# Altium schematic sheet flash.SchDoc(flash)
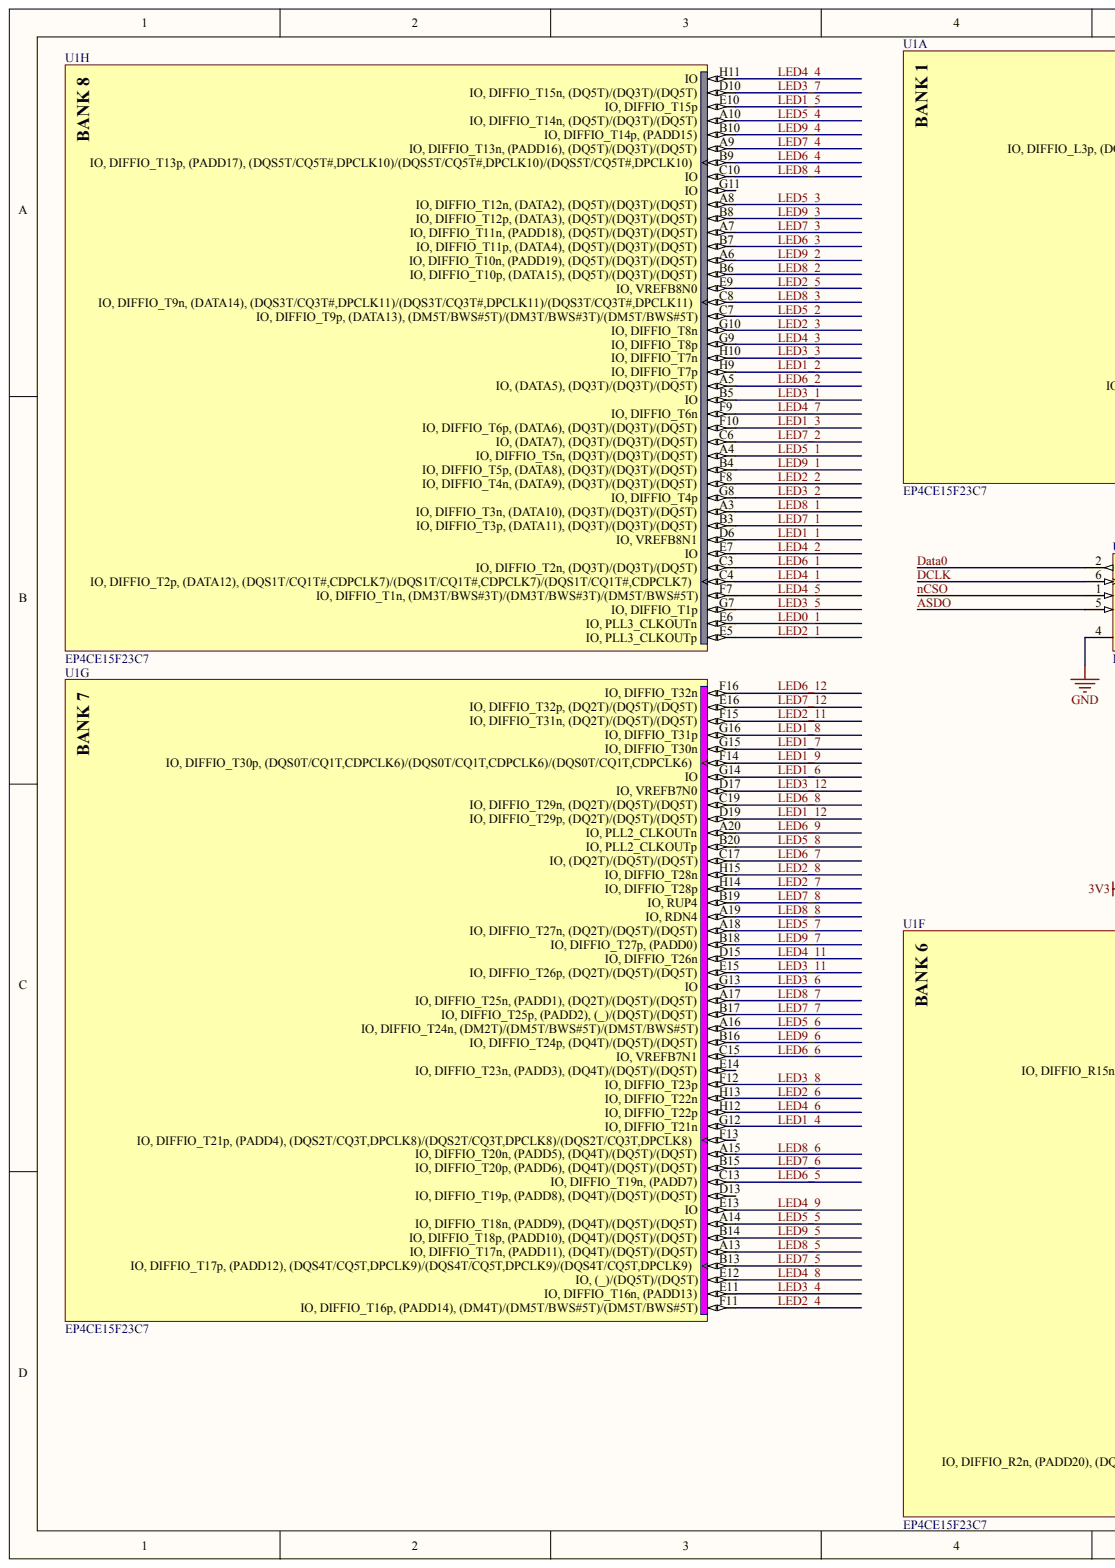
[diagram p0: flash.SchDoc, left]
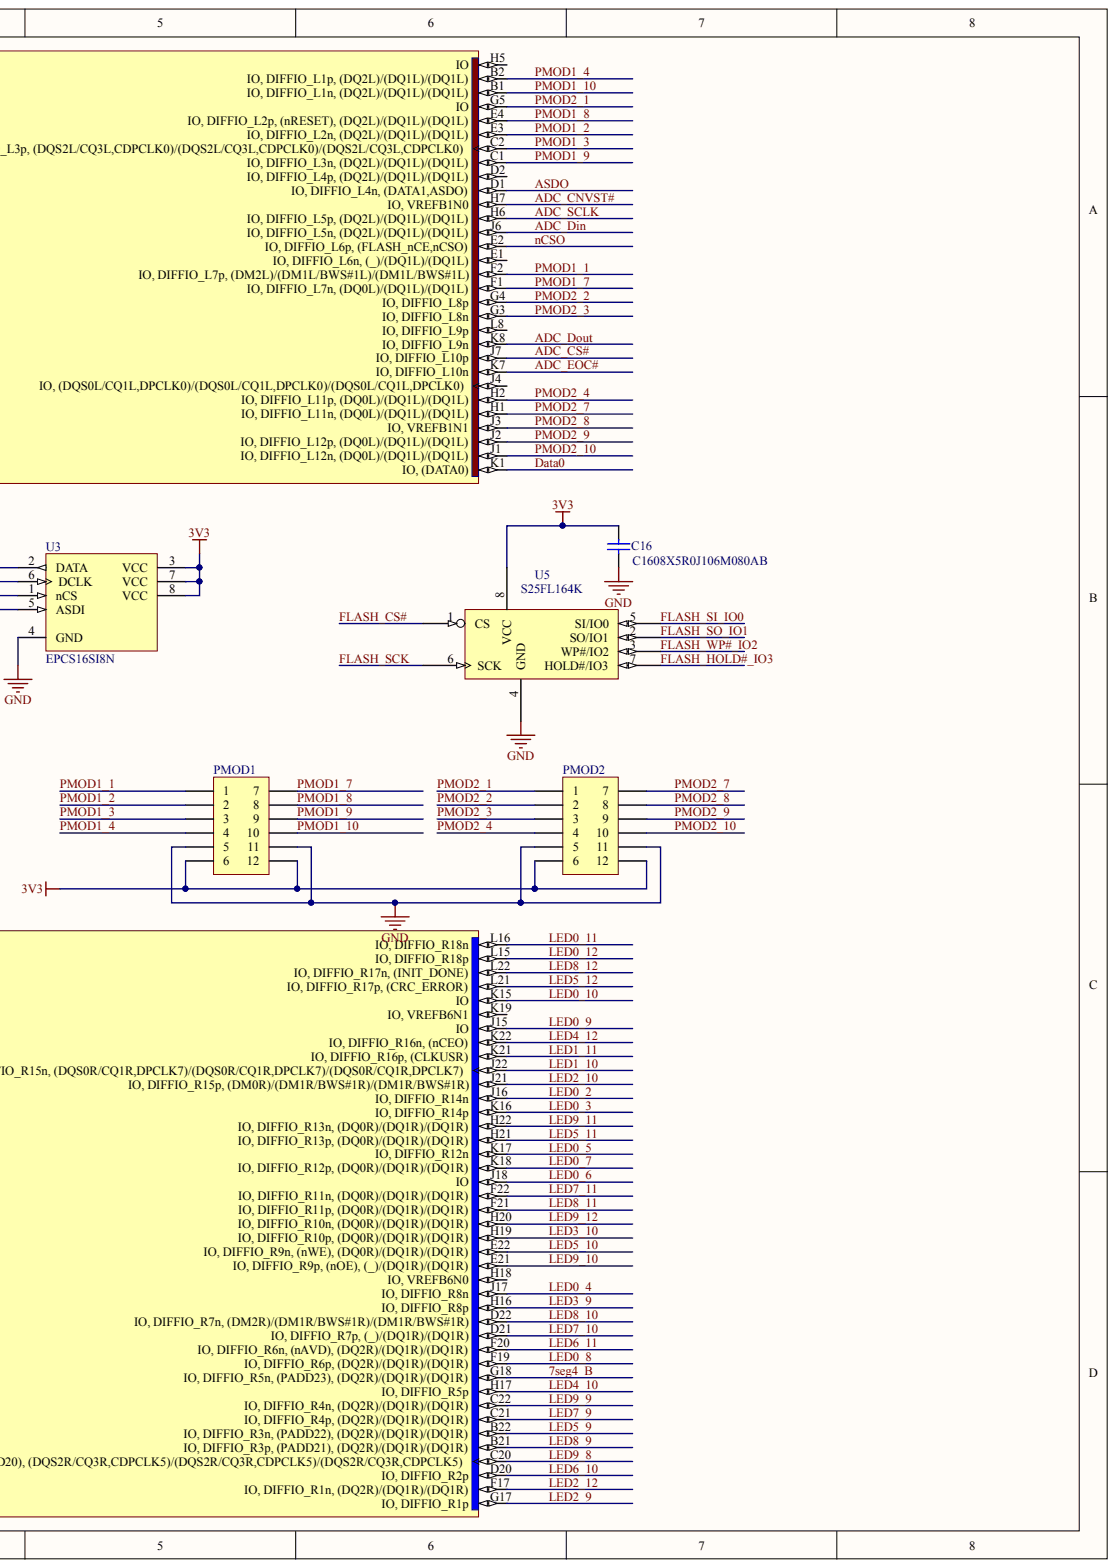
[diagram p1: flash.SchDoc, right]

## Parts
C16  C1608X5R0J106M080AB  [CAPC1608X80]
PMOD1  Header 6X2A  [PMOD_HOST]  (value not drawn)
PMOD2  Header 6X2A  [PMOD_HOST]  (value not drawn)
U1  EP4CE15F23C7  [FBGA484_A-240]  (parts A,F,G,H)
U3  EPCS16SI8N  [SOIC8_N]
U5  S25FL164K  [SOIC127P600X175-8N]

## Nets
3V3: C16.2 PMOD1.6 PMOD1.12 PMOD2.6 PMOD2.12 U3.3(VCC) U3.7(VCC) U3.8(VCC) U5.8(VCC)
GND: C16.1 PMOD1.5 PMOD1.11 PMOD2.5 PMOD2.11 U3.4(GND) U5.4(GND)
7SEG4_B: U1.G18(IO,_DIFFIO_R5n,_(PADD23),_(DQ2R)/(DQ1R)/(DQ1R))
ADC_CNVST#: U1.H7(IO,_VREFB1N0)
ADC_CS#: U1.J7(IO,_DIFFIO_L10p)
ADC_DIN: U1.J6(IO,_DIFFIO_L5n,_(DQ2L)/(DQ1L)/(DQ1L))
ADC_DOUT: U1.K8(IO,_DIFFIO_L9n)
ADC_EOC#: U1.K7(IO,_DIFFIO_L10n)
ADC_SCLK: U1.H6(IO,_DIFFIO_L5p,_(DQ2L)/(DQ1L)/(DQ1L))
ASDO: U1.D1(IO,_DIFFIO_L4n,_(DATA1,ASDO)) U3.5(ASDI)
DATA0: U1.K1(IO,_(DATA0)) U3.2(DATA)
DCLK: U3.6(DCLK)
FLASH_CS#: U5.1(CS)
FLASH_HOLD#_IO3: U5.7(HOLD#/IO3)
FLASH_SCK: U5.6(SCK)
FLASH_SI_IO0: U5.5(SI/IO0)
FLASH_SO_IO1: U5.2(SO/IO1)
FLASH_WP#_IO2: U5.3(WP#/IO2)
LED0_1: U1.E6(IO,_PLL3_CLKOUTn)
LED0_2: U1.J16(IO,_DIFFIO_R14n)
LED0_3: U1.K16(IO,_DIFFIO_R14p)
LED0_4: U1.J17(IO,_DIFFIO_R8n)
LED0_5: U1.K17(IO,_DIFFIO_R12n)
LED0_6: U1.J18(IO)
LED0_7: U1.K18(IO,_DIFFIO_R12p,_(DQ0R)/(DQ1R)/(DQ1R))
LED0_8: U1.F19(IO,_DIFFIO_R6p,_(DQ2R)/(DQ1R)/(DQ1R))
LED0_9: U1.J15(IO)
LED0_10: U1.K15(IO)
LED0_11: U1.L16(IO,_DIFFIO_R18n)
LED0_12: U1.L15(IO,_DIFFIO_R18p)
LED1_1: U1.D6(IO,_VREFB8N1)
LED1_2: U1.H9(IO,_DIFFIO_T7p)
LED1_3: U1.F10(IO,_DIFFIO_T6p,_(DATA6),_(DQ3T)/(DQ3T)/(DQ5T))
LED1_4: U1.G12(IO,_DIFFIO_T21n)
LED1_5: U1.E10(IO,_DIFFIO_T15p)
LED1_6: U1.G14(IO)
LED1_7: U1.G15(IO,_DIFFIO_T30n)
LED1_8: U1.G16(IO,_DIFFIO_T31p)
LED1_9: U1.F14(IO,_DIFFIO_T30p,_(DQS0T/CQ1T,CDPCLK6)/(DQS0T/CQ1T,CDPCLK6)/(DQS0T/CQ1T,CDPCLK6))
LED1_10: U1.J22(IO,_DIFFIO_R15n,_(DQS0R/CQ1R,DPCLK7)/(DQS0R/CQ1R,DPCLK7)/(DQS0R/CQ1R,DPCLK7))
LED1_11: U1.K21(IO,_DIFFIO_R16p,_(CLKUSR))
LED1_12: U1.D19(IO,_DIFFIO_T29p,_(DQ2T)/(DQ5T)/(DQ5T))
LED2_1: U1.E5(IO,_PLL3_CLKOUTp)
LED2_2: U1.F8(IO,_DIFFIO_T4n,_(DATA9),_(DQ3T)/(DQ3T)/(DQ5T))
LED2_3: U1.G10(IO,_DIFFIO_T8n)
LED2_4: U1.F11(IO,_DIFFIO_T16p,_(PADD14),_(DM4T)/(DM5T/BWS#5T)/(DM5T/BWS#5T))
LED2_5: U1.E9(IO,_VREFB8N0)
LED2_6: U1.H13(IO,_DIFFIO_T22n)
LED2_7: U1.H14(IO,_DIFFIO_T28p)
LED2_8: U1.H15(IO,_DIFFIO_T28n)
LED2_9: U1.G17(IO,_DIFFIO_R1p)
LED2_10: U1.J21(IO,_DIFFIO_R15p,_(DM0R)/(DM1R/BWS#1R)/(DM1R/BWS#1R))
LED2_11: U1.F15(IO,_DIFFIO_T31n,_(DQ2T)/(DQ5T)/(DQ5T))
LED2_12: U1.F17(IO,_DIFFIO_R1n,_(DQ2R)/(DQ1R)/(DQ1R))
LED3_1: U1.B5(IO)
LED3_2: U1.G8(IO,_DIFFIO_T4p)
LED3_3: U1.H10(IO,_DIFFIO_T7n)
LED3_4: U1.E11(IO,_DIFFIO_T16n,_(PADD13))
LED3_5: U1.G7(IO,_DIFFIO_T1p)
LED3_6: U1.G13(IO)
LED3_7: U1.D10(IO,_DIFFIO_T15n,_(DQ5T)/(DQ3T)/(DQ5T))
LED3_8: U1.F12(IO,_DIFFIO_T23p)
LED3_9: U1.H16(IO,_DIFFIO_R8p)
LED3_10: U1.H19(IO,_DIFFIO_R10p,_(DQ0R)/(DQ1R)/(DQ1R))
LED3_11: U1.E15(IO,_DIFFIO_T26p,_(DQ2T)/(DQ5T)/(DQ5T))
LED3_12: U1.D17(IO,_VREFB7N0)
LED4_1: U1.C4(IO,_DIFFIO_T2p,_(DATA12),_(DQS1T/CQ1T#,CDPCLK7)/(DQS1T/CQ1T#,CDPCLK7)/(DQS1T/CQ1T#,CDPCLK7))
LED4_2: U1.E7(IO)
LED4_3: U1.G9(IO,_DIFFIO_T8p)
LED4_4: U1.H11(IO)
LED4_5: U1.F7(IO,_DIFFIO_T1n,_(DM3T/BWS#3T)/(DM3T/BWS#3T)/(DM5T/BWS#5T))
LED4_6: U1.H12(IO,_DIFFIO_T22p)
LED4_7: U1.F9(IO,_DIFFIO_T6n)
LED4_8: U1.E12(IO,_(_)/(DQ5T)/(DQ5T))
LED4_9: U1.E13(IO)
LED4_10: U1.H17(IO,_DIFFIO_R5p)
LED4_11: U1.D15(IO,_DIFFIO_T26n)
LED4_12: U1.K22(IO,_DIFFIO_R16n,_(nCEO))
LED5_1: U1.A4(IO,_DIFFIO_T5n,_(DQ3T)/(DQ3T)/(DQ5T))
LED5_2: U1.C7(IO,_DIFFIO_T9p,_(DATA13),_(DM5T/BWS#5T)/(DM3T/BWS#3T)/(DM5T/BWS#5T))
LED5_3: U1.A8(IO,_DIFFIO_T12n,_(DATA2),_(DQ5T)/(DQ3T)/(DQ5T))
LED5_4: U1.A10(IO,_DIFFIO_T14n,_(DQ5T)/(DQ3T)/(DQ5T))
LED5_5: U1.A14(IO,_DIFFIO_T18n,_(PADD9),_(DQ4T)/(DQ5T)/(DQ5T))
LED5_6: U1.A16(IO,_DIFFIO_T24n,_(DM2T)/(DM5T/BWS#5T)/(DM5T/BWS#5T))
LED5_7: U1.A18(IO,_DIFFIO_T27n,_(DQ2T)/(DQ5T)/(DQ5T))
LED5_8: U1.B20(IO,_PLL2_CLKOUTp)
LED5_9: U1.B22(IO,_DIFFIO_R3n,_(PADD22),_(DQ2R)/(DQ1R)/(DQ1R))
LED5_10: U1.E22(IO,_DIFFIO_R9n,_(nWE),_(DQ0R)/(DQ1R)/(DQ1R))
LED5_11: U1.H21(IO,_DIFFIO_R13p,_(DQ0R)/(DQ1R)/(DQ1R))
LED5_12: U1.L21(IO,_DIFFIO_R17p,_(CRC_ERROR))
LED6_1: U1.C3(IO,_DIFFIO_T2n,_(DQ3T)/(DQ3T)/(DQ5T))
LED6_2: U1.A5(IO,_(DATA5),_(DQ3T)/(DQ3T)/(DQ5T))
LED6_3: U1.B7(IO,_DIFFIO_T11p,_(DATA4),_(DQ5T)/(DQ3T)/(DQ5T))
LED6_4: U1.B9(IO,_DIFFIO_T13p,_(PADD17),_(DQS5T/CQ5T#,DPCLK10)/(DQS5T/CQ5T#,DPCLK10)/(DQS5T/CQ5T#,DPCLK10))
LED6_5: U1.C13(IO,_DIFFIO_T19n,_(PADD7))
LED6_6: U1.C15(IO,_VREFB7N1)
LED6_7: U1.C17(IO,_(DQ2T)/(DQ5T)/(DQ5T))
LED6_8: U1.C19(IO,_DIFFIO_T29n,_(DQ2T)/(DQ5T)/(DQ5T))
LED6_9: U1.A20(IO,_PLL2_CLKOUTn)
LED6_10: U1.D20(IO,_DIFFIO_R2p)
LED6_11: U1.F20(IO,_DIFFIO_R6n,_(nAVD),_(DQ2R)/(DQ1R)/(DQ1R))
LED6_12: U1.F16(IO,_DIFFIO_T32n)
LED7_1: U1.B3(IO,_DIFFIO_T3p,_(DATA11),_(DQ3T)/(DQ3T)/(DQ5T))
LED7_2: U1.C6(IO,_(DATA7),_(DQ3T)/(DQ3T)/(DQ5T))
LED7_3: U1.A7(IO,_DIFFIO_T11n,_(PADD18),_(DQ5T)/(DQ3T)/(DQ5T))
LED7_4: U1.A9(IO,_DIFFIO_T13n,_(PADD16),_(DQ5T)/(DQ3T)/(DQ5T))
LED7_5: U1.B13(IO,_DIFFIO_T17p,_(PADD12),_(DQS4T/CQ5T,DPCLK9)/(DQS4T/CQ5T,DPCLK9)/(DQS4T/CQ5T,DPCLK9))
LED7_6: U1.B15(IO,_DIFFIO_T20p,_(PADD6),_(DQ4T)/(DQ5T)/(DQ5T))
LED7_7: U1.B17(IO,_DIFFIO_T25p,_(PADD2),_(_)/(DQ5T)/(DQ5T))
LED7_8: U1.B19(IO,_RUP4)
LED7_9: U1.C21(IO,_DIFFIO_R4p,_(DQ2R)/(DQ1R)/(DQ1R))
LED7_10: U1.D21(IO,_DIFFIO_R7p,_(_)/(DQ1R)/(DQ1R))
LED7_11: U1.F22(IO,_DIFFIO_R11n,_(DQ0R)/(DQ1R)/(DQ1R))
LED7_12: U1.E16(IO,_DIFFIO_T32p,_(DQ2T)/(DQ5T)/(DQ5T))
LED8_1: U1.A3(IO,_DIFFIO_T3n,_(DATA10),_(DQ3T)/(DQ3T)/(DQ5T))
LED8_2: U1.B6(IO,_DIFFIO_T10p,_(DATA15),_(DQ5T)/(DQ3T)/(DQ5T))
LED8_3: U1.C8(IO,_DIFFIO_T9n,_(DATA14),_(DQS3T/CQ3T#,DPCLK11)/(DQS3T/CQ3T#,DPCLK11)/(DQS3T/CQ3T#,DPCLK11))
LED8_4: U1.C10(IO)
LED8_5: U1.A13(IO,_DIFFIO_T17n,_(PADD11),_(DQ4T)/(DQ5T)/(DQ5T))
LED8_6: U1.A15(IO,_DIFFIO_T20n,_(PADD5),_(DQ4T)/(DQ5T)/(DQ5T))
LED8_7: U1.A17(IO,_DIFFIO_T25n,_(PADD1),_(DQ2T)/(DQ5T)/(DQ5T))
LED8_8: U1.A19(IO,_RDN4)
LED8_9: U1.B21(IO,_DIFFIO_R3p,_(PADD21),_(DQ2R)/(DQ1R)/(DQ1R))
LED8_10: U1.D22(IO,_DIFFIO_R7n,_(DM2R)/(DM1R/BWS#1R)/(DM1R/BWS#1R))
LED8_11: U1.F21(IO,_DIFFIO_R11p,_(DQ0R)/(DQ1R)/(DQ1R))
LED8_12: U1.L22(IO,_DIFFIO_R17n,_(INIT_DONE))
LED9_1: U1.B4(IO,_DIFFIO_T5p,_(DATA8),_(DQ3T)/(DQ3T)/(DQ5T))
LED9_2: U1.A6(IO,_DIFFIO_T10n,_(PADD19),_(DQ5T)/(DQ3T)/(DQ5T))
LED9_3: U1.B8(IO,_DIFFIO_T12p,_(DATA3),_(DQ5T)/(DQ3T)/(DQ5T))
LED9_4: U1.B10(IO,_DIFFIO_T14p,_(PADD15))
LED9_5: U1.B14(IO,_DIFFIO_T18p,_(PADD10),_(DQ4T)/(DQ5T)/(DQ5T))
LED9_6: U1.B16(IO,_DIFFIO_T24p,_(DQ4T)/(DQ5T)/(DQ5T))
LED9_7: U1.B18(IO,_DIFFIO_T27p,_(PADD0))
LED9_8: U1.C20(IO,_DIFFIO_R2n,_(PADD20),_(DQS2R/CQ3R,CDPCLK5)/(DQS2R/CQ3R,CDPCLK5)/(DQS2R/CQ3R,CDPCLK5))
LED9_9: U1.C22(IO,_DIFFIO_R4n,_(DQ2R)/(DQ1R)/(DQ1R))
LED9_10: U1.E21(IO,_DIFFIO_R9p,_(nOE),_(_)/(DQ1R)/(DQ1R))
LED9_11: U1.H22(IO,_DIFFIO_R13n,_(DQ0R)/(DQ1R)/(DQ1R))
LED9_12: U1.H20(IO,_DIFFIO_R10n,_(DQ0R)/(DQ1R)/(DQ1R))
NCSO: U1.E2(IO,_DIFFIO_L6p,_(FLASH_nCE,nCSO)) U3.1(nCS)
PMOD1_1: PMOD1.1 U1.F2(IO,_DIFFIO_L7p,_(DM2L)/(DM1L/BWS#1L)/(DM1L/BWS#1L))
PMOD1_2: PMOD1.2 U1.E3(IO,_DIFFIO_L2n,_(DQ2L)/(DQ1L)/(DQ1L))
PMOD1_3: PMOD1.3 U1.C2(IO,_DIFFIO_L3p,_(DQS2L/CQ3L,CDPCLK0)/(DQS2L/CQ3L,CDPCLK0)/(DQS2L/CQ3L,CDPCLK0))
PMOD1_4: PMOD1.4 U1.B2(IO,_DIFFIO_L1p,_(DQ2L)/(DQ1L)/(DQ1L))
PMOD1_7: PMOD1.7 U1.F1(IO,_DIFFIO_L7n,_(DQ0L)/(DQ1L)/(DQ1L))
PMOD1_8: PMOD1.8 U1.E4(IO,_DIFFIO_L2p,_(nRESET),_(DQ2L)/(DQ1L)/(DQ1L))
PMOD1_9: PMOD1.9 U1.C1(IO,_DIFFIO_L3n,_(DQ2L)/(DQ1L)/(DQ1L))
PMOD1_10: PMOD1.10 U1.B1(IO,_DIFFIO_L1n,_(DQ2L)/(DQ1L)/(DQ1L))
PMOD2_1: PMOD2.1 U1.G5(IO)
PMOD2_2: PMOD2.2 U1.G4(IO,_DIFFIO_L8p)
PMOD2_3: PMOD2.3 U1.G3(IO,_DIFFIO_L8n)
PMOD2_4: PMOD2.4 U1.H2(IO,_DIFFIO_L11p,_(DQ0L)/(DQ1L)/(DQ1L))
PMOD2_7: PMOD2.7 U1.H1(IO,_DIFFIO_L11n,_(DQ0L)/(DQ1L)/(DQ1L))
PMOD2_8: PMOD2.8 U1.J3(IO,_VREFB1N1)
PMOD2_9: PMOD2.9 U1.J2(IO,_DIFFIO_L12p,_(DQ0L)/(DQ1L)/(DQ1L))
PMOD2_10: PMOD2.10 U1.J1(IO,_DIFFIO_L12n,_(DQ0L)/(DQ1L)/(DQ1L))

Not connected: U1 D2(IO,_DIFFIO_L4p,_(DQ2L)/(DQ1L)/(DQ1L)) D13(IO,_DIFFIO_T19p,_(PADD8),_(DQ4T)/(DQ5T)/(DQ5T)) E1(IO,_DIFFIO_L6n,_(_)/(DQ1L)/(DQ1L)) E14(IO,_DIFFIO_T23n,_(PADD3),_(DQ4T)/(DQ5T)/(DQ5T)) F13(IO,_DIFFIO_T21p,_(PADD4),_(DQS2T/CQ3T,DPCLK8)/(DQS2T/CQ3T,DPCLK8)/(DQS2T/CQ3T,DPCLK8)) G11(IO) H5(IO) H18(IO,_VREFB6N0) J4(IO,_(DQS0L/CQ1L,DPCLK0)/(DQS0L/CQ1L,DPCLK0)/(DQS0L/CQ1L,DPCLK0)) K19(IO,_VREFB6N1) L8(IO,_DIFFIO_L9p)

## Library data of the parts
C16 = Cap: Capacitor; Component Kind: Standard; LatestRevisionDate: 17-Jul-2002; LatestRevisionNote: Re-released for DXP Platform.; Library Name: Miscellaneous Devices.IntLib; PackageDescription: Radial Cap, Thru-Hole; 2 Leads; 0.3 in Pin Spacing; PackageReference: RAD-0.3; Published: 8-Jun-2000; Signal Integrity: Cap; Simulation: CAP; Value: C1608X5R0J106M080AB; Publisher: Altium Limited
PMOD1 PMOD2 = Header 6X2A: Header, 6-Pin, Dual row; Component Kind: Standard; LatestRevisionDate: 17-Jul-2002; LatestRevisionNote: Re-released for DXP Platform.; Library Name: Miscellaneous Connectors.IntLib; Signal Integrity: Connector; Publisher: Altium Limited
U1 = EP4CE15F23C7: Cyclone IV Family FPGA, 1.2V Core, 343 I/O Pins, 4 PLLs, 484-Pin FBGA, Speed Grade 7, Commercial Grade; Manufacturer: Altera; Code_JEDEC: MS-034-AAJ-1; Component Kind: Standard; ComponentLink1Description: Manufacturer URL; ComponentLink1URL: http://www.altera.com/; ComponentLink2Description: Handbook; ComponentLink2URL: http://www.altera.com/literature/hb/cyclone-iv/cyclone4-handbook.pdf; ComponentLink3Description: Pinout; ComponentLink3URL: http://www.altera.com/literature/lit-dp.jsp?category=cyc%204&showspreadsheet=y; ComponentLink4Description: Package Specification; ComponentLink4URL: http://www.altera.com/devicepackaging/04R00247-02.pdf; DatasheetVersion: ver1.6, Nov-2011; Library Name: Altera Cyclone IV E.IntLib; PackageDescription: 484-Pin FineLine Ball Grid Array (FBGA) - Wire Bond - A:2.40; PackageReference: FBGA484_A-240; PackageVersion: ver1.0, Jun-2011
U3 = EPCS16SI8N: EPCS16 Series, In-system programmable 3.3V serial configuration device, 8-Pin SOIC, 16-Megabit, Industrial Grade, Pb-Free; Configures: All Arria series, all Cyclone series and all Stratix series (except Stratix); Code_IPC: SOIC127P600-8; Code_JEDEC: MS-012-AA; Component Kind: Standard; ComponentLink1Description: Manufacturer URL; ComponentLink1URL: http://www.altera.com/; ComponentLink2Description: Configuration Handbook; ComponentLink2URL: http://www.altera.com/literature/hb/cfg/config_handbook.pdf; ComponentLink3Description: Pinout; ComponentLink3URL: http://www.altera.com/literature/hb/cfg/config_handbook.pdf; ComponentLink4Description: Package Specification; ComponentLink4URL: http://www.altera.com/support/devices/packaging/specifications/pkg-pin/pdf/016-SOIC.pdf; DatasheetVersion: ver3.0, Dec-2009; Library Name: Altera EPCS.IntLib; PackageDescription: 8-Pin Small Outline Integrated Circuit Package (SOIC8); PackageReference: SOIC8; PackageVersion: ver14.2, Oct-2005
U5 = S25FL1x: VALUE: S25FL1xx; Component Kind: Standard; Library Name: geckoedu.SchLib
